# Altium schematic sheet OSP_Carrier_V7_Release_PMIC_Audio.SchDoc
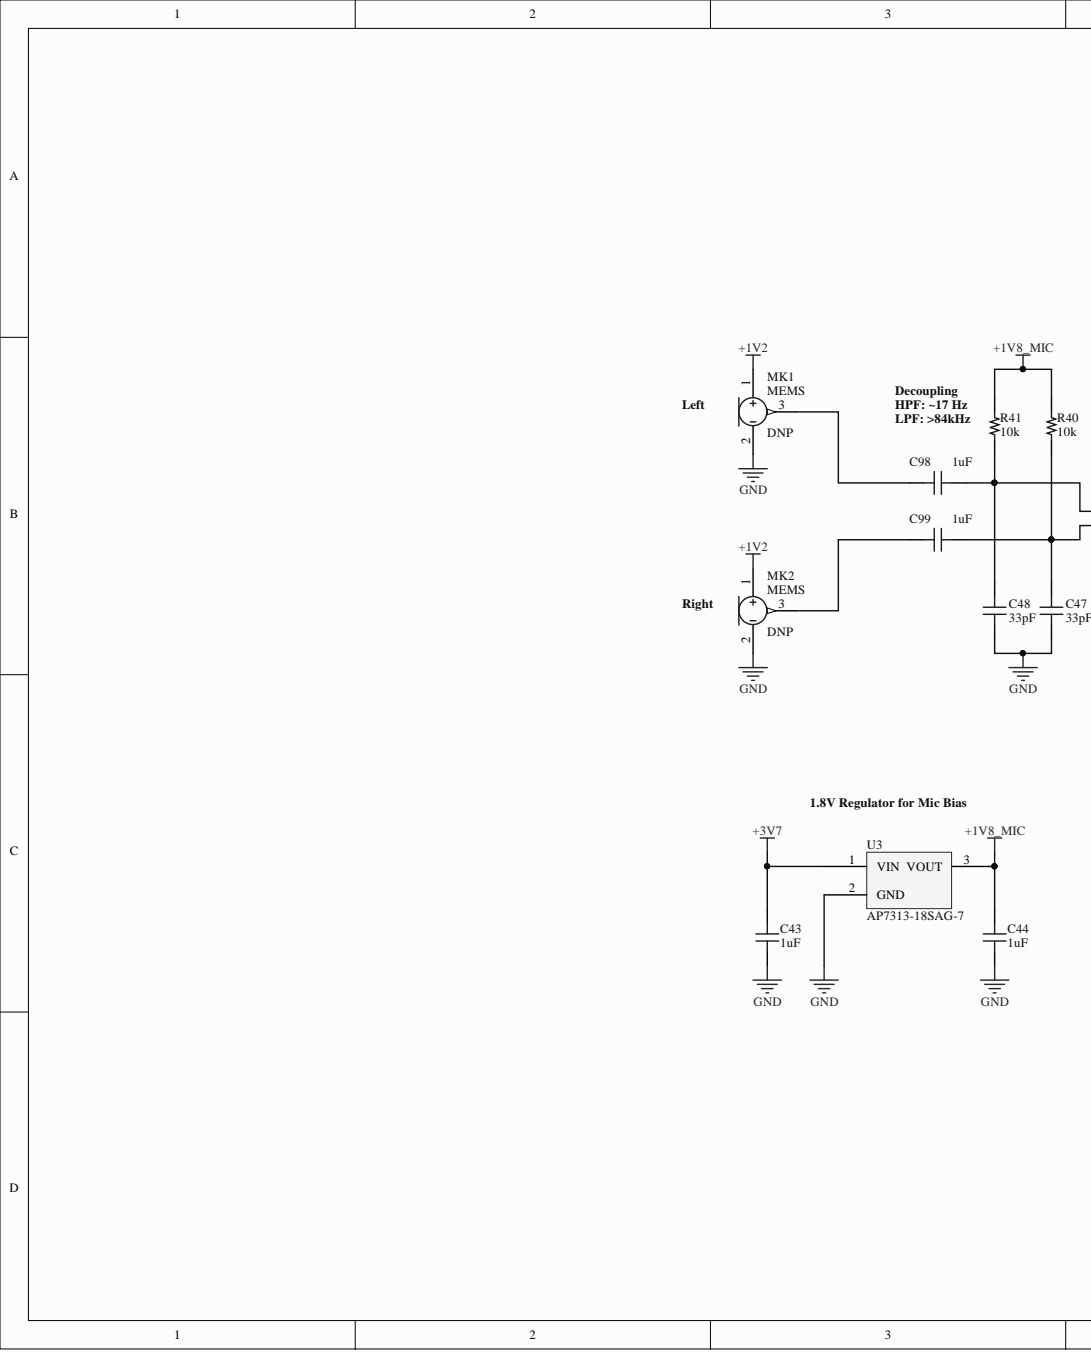
[diagram p0: OSP_Carrier_V7_Release_PMIC_Audio.SchDoc, left]
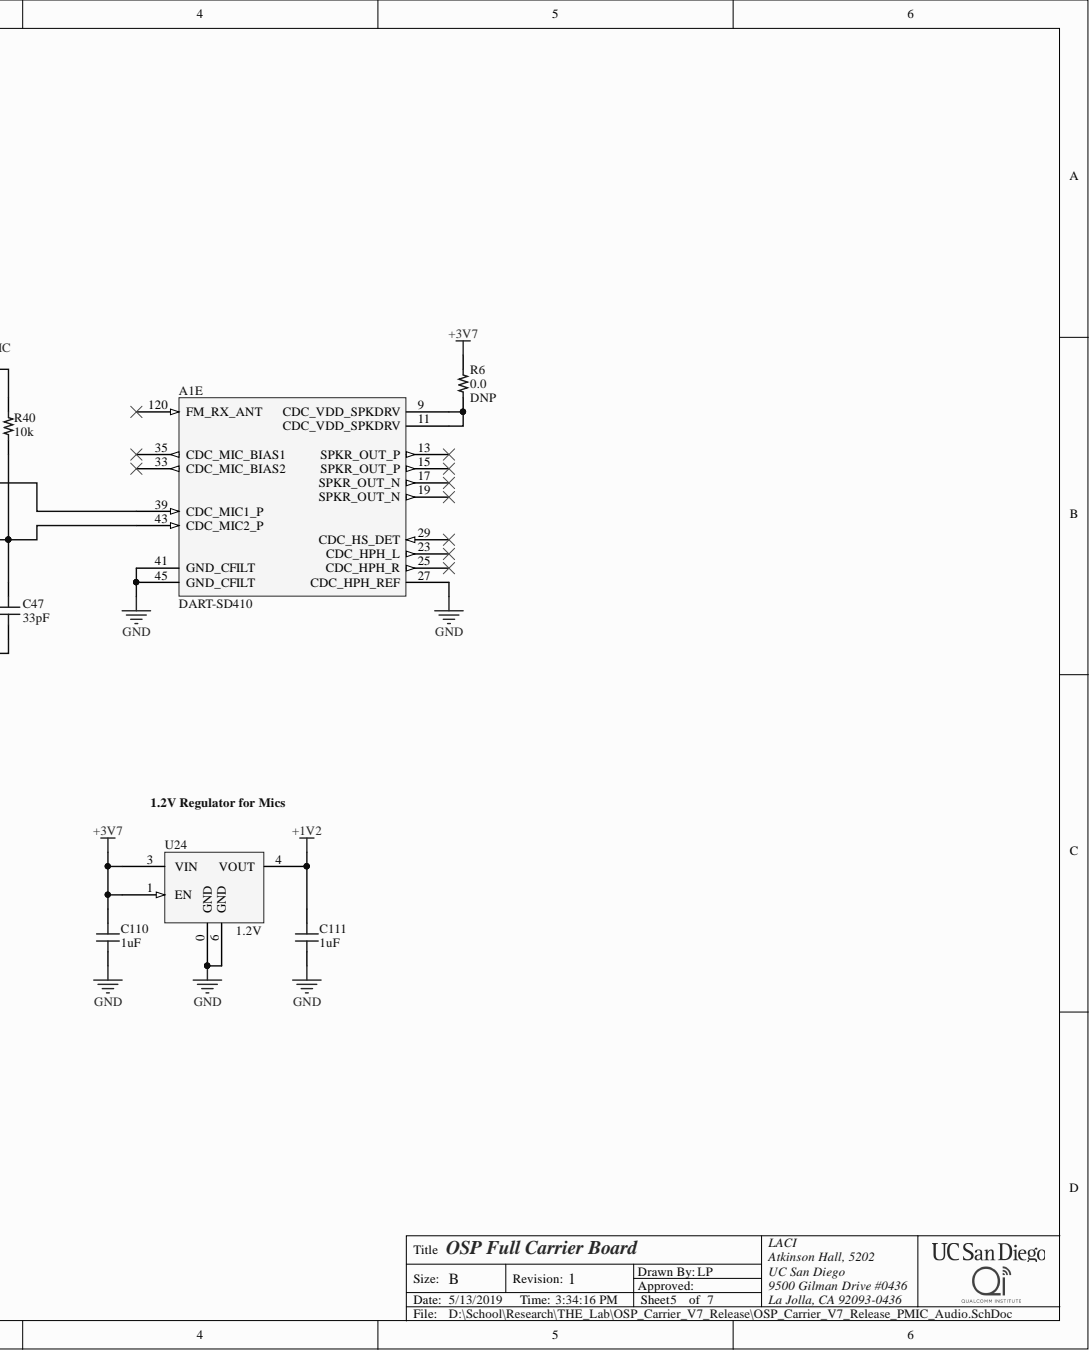
[diagram p1: OSP_Carrier_V7_Release_PMIC_Audio.SchDoc, right]

## Parts
A1  DART-SD410  [DART-SD410]  (part E)
C43  1uF  [CAP 0402/1005]
C44  1uF  [CAP 0402/1005]
C47  33pF  [CAP 0402/1005]
C48  33pF  [CAP 0402/1005]
C98  1uF  [CAP 0603/1608]
C99  1uF  [CAP 0603/1608]
C110  1uF  [CAP 0402/1005]
C111  1uF  [CAP 0402/1005]
MK1  MEMS  [MEMS_MIC]
MK2  MEMS  [MEMS_MIC]
R6  0.0  [RES 0603/1608]
R40  10k  [RES 0402/1005]
R41  10k  [RES 0402/1005]
U3  AP7313-18SAG-7  [DIODES SOT-23-3]
U24  1.2V  [MICROCHIP_MIC5512]

## Nets
+1V2: C111.1 MK1.1 MK2.1 U24.4(VOUT)
+1V8_MIC: C44.1 R40.2 R41.2 U3.3
+3V7: C43.1 C110.1 R6.2 U3.1 U24.1(EN) U24.3(VIN)
GND: A1.27(CDC_HPH_REF) A1.41(GND_CFILT) A1.45(GND_CFILT) C43.2 C44.2 C47.1 C48.1 C110.2 C111.2 MK1.2 MK2.2 U3.2 U24.0(GND) U24.6(GND)
NetA1_9: A1.9(CDC_VDD_SPKDRV) A1.11(CDC_VDD_SPKDRV) R6.1
NetA1_39: A1.39(CDC_MIC1_P) C48.2 C98.1 R41.1
NetA1_43: A1.43(CDC_MIC2_P) C47.2 C99.1 R40.1
NetC98_2: C98.2 MK1.3
NetC99_2: C99.2 MK2.3

Not connected: A1 13(SPKR_OUT_P) 15(SPKR_OUT_P) 17(SPKR_OUT_N) 19(SPKR_OUT_N) 23(CDC_HPH_L) 25(CDC_HPH_R) 29(CDC_HS_DET) 33(CDC_MIC_BIAS2) 35(CDC_MIC_BIAS1) 120(FM_RX_ANT)

## Library data of the parts
A1 = DART-SD410: Variscite DART-SD410 System on Module; Component Kind: Standard; Library Name: DART-SD410.SchLib
C43 C44 C110 C111 = GRM155R61A105KE15D: CAP CER 1UF 10V X5R 0402; Manufacturer: Murata Electronics North America; Database Table Name: Capacitor - Ceramic; TemperatureRange: -55C ~ 85C; Tolerance: 10%; Component Kind: Standard; ComponentLink1Description: Datasheet; ComponentLink1URL: http://www.murata.com/~/media/webrenewal/support/library/catalog/products/capacitor/mlcc/c02e.pdf; ComponentLink2Description: Digi-Key Listing; ComponentLink2URL: https://www.digikey.com/product-detail/en/murata-electronics-north-america/GRM155R61A105KE15D/490-3890-2-ND/965904; Device Package: 0402 (1005 Metric); Library Name: Celestial Library Database.DbLib; PartId: 12338; Part Number: GRM155R61A105KE15D; Price: 0.0069; Supplier 1: Digi-Key; Supplier Part Number 1: 490-3890-2-ND; TemperatureCoefficient: X5R; Value: 1uF; Voltage: 10V
C47 C48 = C1005C0G1H330J050BA: CAP CER 33PF 50V C0G 0402; Manufacturer: TDK Corporation; Database Table Name: Capacitor - Ceramic; TemperatureCoefficient: C0G, NP0; TemperatureRange: -55C ~ 125C; Tolerance: 5%; Component Kind: Standard; ComponentLink1Description: Datasheet; ComponentLink1URL: https://product.tdk.com/info/en/catalog/datasheets/mlcc_commercial_general_en.pdf; ComponentLink2Description: Digi-Key listing; ComponentLink2URL: http://digikey.com/product-detail/en/C1005C0G1H330J050BA/445-1241-2-ND/513687; Device Package: 0402 (1005 Metric); Library Name: Celestial Library Database.DbLib; PartId: 3877; Part Number: C1005C0G1H330J050BA; Price: 0.006; Supplier 1: Digi-Key; Supplier Part Number 1: 445-1241-2-ND; Type: -; Value: 33pF; Voltage: 50V
C98 C99 = C1608X7R1E105K080AB: CAP CER 1UF 25V X7R 0603; Manufacturer: TDK Corporation; Database Table Name: Capacitor - Ceramic; TemperatureRange: -55C ~ 125C; Tolerance: 10%; Component Kind: Standard; ComponentLink1Description: Datasheet; ComponentLink1URL: https://product.tdk.com/info/en/catalog/datasheets/mlcc_commercial_general_en.pdf; ComponentLink2Description: Digi-Key listing; ComponentLink2URL: http://digikey.com/product-detail/en/C1608X7R1E105K080AB/445-5956-1-ND/2443997; Device Package: 0603 (1608 Metric); Library Name: Celestial Library Database.DbLib; PartId: 4041; Part Number: C1608X7R1E105K080AB; Price: 0.27; Supplier 1: Digi-Key; Supplier Part Number 1: 445-5956-1-ND; TemperatureCoefficient: X7R; Type: -; Value: 1uF; Voltage: 25V
MK1 MK2 = Mems_Mic: Generic 3-pin bottom mount MEMS mic; Component Kind: Standard; DNP: DNP; Library Name: OSP_Symbols.SchLib
R6 = CRCW06030000Z0EAHP: RES SMD 0.0 OHM JUMPER 1/4W 0603; Manufacturer: Vishay Dale; Database Table Name: Resistor - Chip; PowerRating: 0.25W, 1/4W; TemperatureRange: -55C ~ 155C; Component Kind: Standard; ComponentLink1Description: Datasheet; ComponentLink1URL: http://www.vishay.com/docs/20043/crcwhpe3.pdf; ComponentLink2Description: Digi-Key Listing; ComponentLink2URL: https://www.digikey.com/product-detail/en/vishay-dale/CRCW06030000Z0EAHP/541-0.0SBTR-ND/2222231; Device Package: 0603 (1608 Metric); DNP: DNP; Library Name: Celestial Library Database.DbLib; PartId: 16113; Part Number: CRCW06030000Z0EAHP; Price: 0.0238; Supplier 1: Digi-Key; Supplier Part Number 1: 541-0.0SBTR-ND; Tolerance: Jumper; Value: 0.0
R40 R41 = ERJ-2RKF1002X: RES SMD 10K OHM 1% 1/10W 0402; Manufacturer: Panasonic Electronic Components; Database Table Name: Resistor - Chip; PowerRating: 0.1W, 1/10W; TemperatureCoefficient: 100ppm/C; TemperatureRange: -55C ~ 155C; Component Kind: Standard; ComponentLink1Description: Datasheet; ComponentLink1URL: http://industrial.panasonic.com/www-cgi/jvcr13pz.cgi?E+PZ+3+AOA0002+ERJ2RKF1002X+7+WW; ComponentLink2Description: Digikey listing; ComponentLink2URL: http://digikey.com/product-detail/en/ERJ-2RKF1002X/P10.0KLTR-ND/192073; Device Package: 0402 (1005 Metric); Library Name: Celestial Library Database.DbLib; PartId: 1; Part Number: ERJ-2RKF1002X; Price: 0.0043; Supplier 1: Digi-Key; Supplier Part Number 1: P10.0KLTR-ND; Tolerance: 1%; Type: Thick Film; Value: 10k
U3 = AP7313-18SAG-7: IC REG LDO 1.8V 0.15A SOT23-3; Manufacturer: Diodes Incorporated; Current - Limit (Min): 200mA; Database Table Name: Voltage Regulator - Linear; Topology: Positive Fixed; Voltage - Input: 2 V ~ 6 V; Component Kind: Standard; ComponentLink1Description: Datasheet; ComponentLink1URL: http://www.diodes.com/_files/datasheets/AP7313.pdf; ComponentLink2Description: Digi-Key Listing; ComponentLink2URL: http://www.digikey.ca/product-detail/en/AP7313-18SAG-7/AP7313-18SAG-7DITR-ND/2270804; Device Package: TO-236-3, SC-59, SOT-23-3; Library Name: Celestial Library Database.DbLib; Operating Temperature: -40C ~ 85C; PartId: 7912; Part Number: AP7313-18SAG-7; Price: 0.1675; Supplier 1: Digi-Key; Supplier Part Number 1: AP7313-18SAG-7DITR-ND; Voltage - Output: 1.8V; Voltage Dropout: -
U24 = Microchip_MIC5512-1.2YMT: Microchip MIC5512-1.2YMT 1.2V 300mA linear regulator; Component Kind: Standard; Library Name: OSP_Symbols.SchLib
